# Altium schematic sheet UART-USB.SchDoc
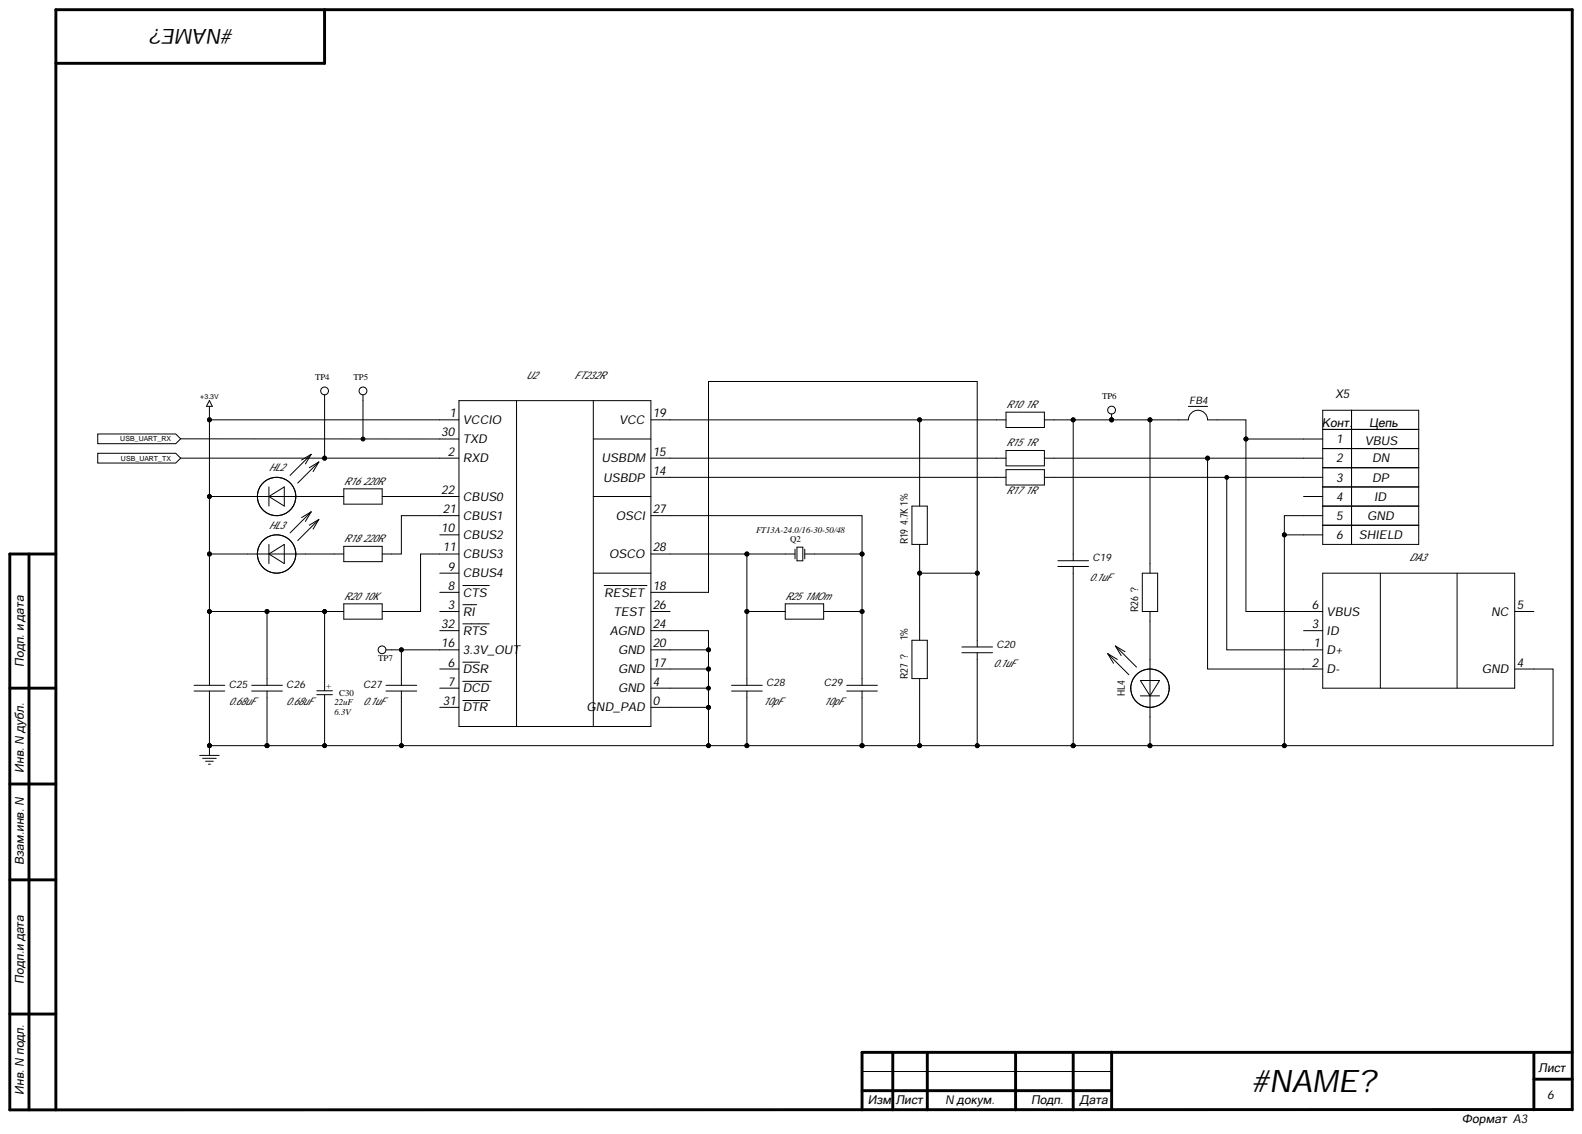
[diagram p0: UART-USB.SchDoc]

## Parts
C19  value 0.1uF  (hidden comment: Cap)
C20  value 0.1uF  (hidden comment: Cap)
C25  value 0.68uF  (hidden comment: Cap)
C26  value 0.68uF  (hidden comment: Cap)
C27  value 0.1uF  (hidden comment: Cap)
C28  value 10pF  (hidden comment: Cap)
C29  value 10pF  (hidden comment: Cap)
C30  value 22uF  Voltage: 6.3V  [(B)3528]  (hidden comment: сap)
DA3  (no value)
FB4  (no value)
HL2  (no value)
HL3  (no value)
HL4  (no value)
Q2  value FT13A-24.0/16-30-50/48  [OSC-7M]  (hidden comment: XTAL)
R10  value 1R  (hidden comment: Res2)
R15  value 1R  (hidden comment: Res2)
R16  value 220R  (hidden comment: Res2)
R17  value 1R  (hidden comment: Res2)
R18  value 220R  (hidden comment: Res2)
R19  value 4.7K  Precision: 1%  (hidden comment: Res2)
R20  value 10K  (hidden comment: Res2)
R25  value 1MOm  (hidden comment: Res2)
R26  Res2  (value not drawn)
R27  Precision: 1%  (hidden comment: Res2)
TP4  (no value)  [TP_0MM5_1MM0]  (no BOM)
TP5  (no value)  [TP_0MM5_1MM0]  (no BOM)
TP6  (no value)  [TP_0MM5_1MM0]  (no BOM)
TP7  (no value)  [TP_0MM5_1MM0]  (no BOM)
U2  FT232R  [Qfn32-ftdi]
X5  Circuit 1: VBUS  Circuit 2: DN  Circuit 3: DP  Circuit 4: ID  Circuit 5: GND  Circuit 6: SHIELD

## Ports
USB_UART_RX, USB_UART_TX

## Nets
+3.3V: C25.2 C26.2 C30.1 HL2.2 HL3.2 R20.2 U2.1(VCCIO)
GND: C19.2 C20.2 C25.1 C26.1 C27.1 C28.2 C29.2 C30.2 DA3.4(GND) HL4.2 R27.1 U2.0(GND_PAD) U2.4(GND) U2.17(GND) U2.20(GND) U2.24(AGND) X5.5 X5.6
USB_UART_RX: TP5.1 U2.30(TXD) ; port USB_UART_RX
USB_UART_TX: TP4.1 U2.2(RXD) ; port USB_UART_TX
NetC19_1: C19.1 FB4.1 R10.1 R26.1 TP6.1
NetC20_1: C20.1 R19.1 R27.2 U2.18(RESET)
NetC27_2: C27.2 TP7.1 U2.16(3.3V_OUT)
NetC28_1: C28.1 Q2.1 R25.2 U2.28(OSCO)
NetC29_1: C29.1 Q2.3 R25.1 U2.27(OSCI)
NetDA3_1: DA3.1(D+) R17.2 X5.3
NetDA3_2: DA3.2(D-) R15.2 X5.2
NetDA3_6: DA3.6(VBUS) FB4.2 X5.1
NetHL2_1: HL2.1 R16.2
NetHL3_1: HL3.1 R18.2
NetHL4_1: HL4.1 R26.2
NetR10_2: R10.2 R19.2 U2.19(VCC)
NetR15_1: R15.1 U2.15(USBDM)
NetR16_1: R16.1 U2.22(CBUS0)
NetR17_1: R17.1 U2.14(USBDP)
NetR18_1: R18.1 U2.21(CBUS1)
NetR20_1: R20.1 U2.11(CBUS3)

Not connected: DA3 3(ID) 5(NC); U2 3(RI) 6(DSR) 7(DCD) 8(CTS) 9(CBUS4) 10(CBUS2) 26(TEST) 31(DTR) 32(RTS); X5 4

## Library data of the parts
C19 C20 C26-C29 = Cap: Конденсатор; Component Kind: Standard; PackageReference: 0603; Signal Integrity: Cap; Library Name: Terra.SCHLIB
C25 = Cap: Конденсатор; Value: 0.68uF; Component Kind: Standard; PackageReference: 0603; Signal Integrity: Cap; Library Name: Terra.SCHLIB
C30 = PCap: Конденсатор керамический; Info: X5R 10%  GRM32ER61A226; Component Kind: Standard; Library Name: common.SCHLIB; PackageReference: "B" 3528; Signal Integrity: Cap; Simulation: CAP
DA3 = TPD4S012DRYR: 4-Channel ESD Solution for USB-HS/USB OTG/USB; Component Kind: Standard; Value: TPD4S012DRYR
FB4 = Inductor: Component Kind: Standard
HL2-HL4 = DIODE-LED-GOST: Component Kind: Standard
Q2 = XTAL: Кварцевый резонатор; Component Kind: Standard; Library Name: common.SCHLIB; PackageReference: KX7; PCB3D: SW3dPS-hc49; Simulation: XTAL
R10 R15-R20 R25-R27 = Res2: Резистор; Component Kind: Standard; PackageReference: 0603; Signal Integrity: Res; Library Name: Terra.SCHLIB
  R26 R27: Value: ?
TP4-TP7 = Test_Point: Тестовая точка на плате; MarkPCB: +5V
U2 = FT232R_2: IC USB FS SERIAL UART 32-QFN; Manufacturer Part Num: FT232RQ-REEL; Part Class: ICS; Device: U17; Item Num: P01-17819R; Num Pins: 32; Component Kind: Standard; Library Name: common.SCHLIB; PCB Footprint: Qfn32-ftdi
X5 = CONNECTOR_6: Component Kind: Standard; System: connector
